# Altium schematic sheet 定位主控板.SchDoc(定位主控板)
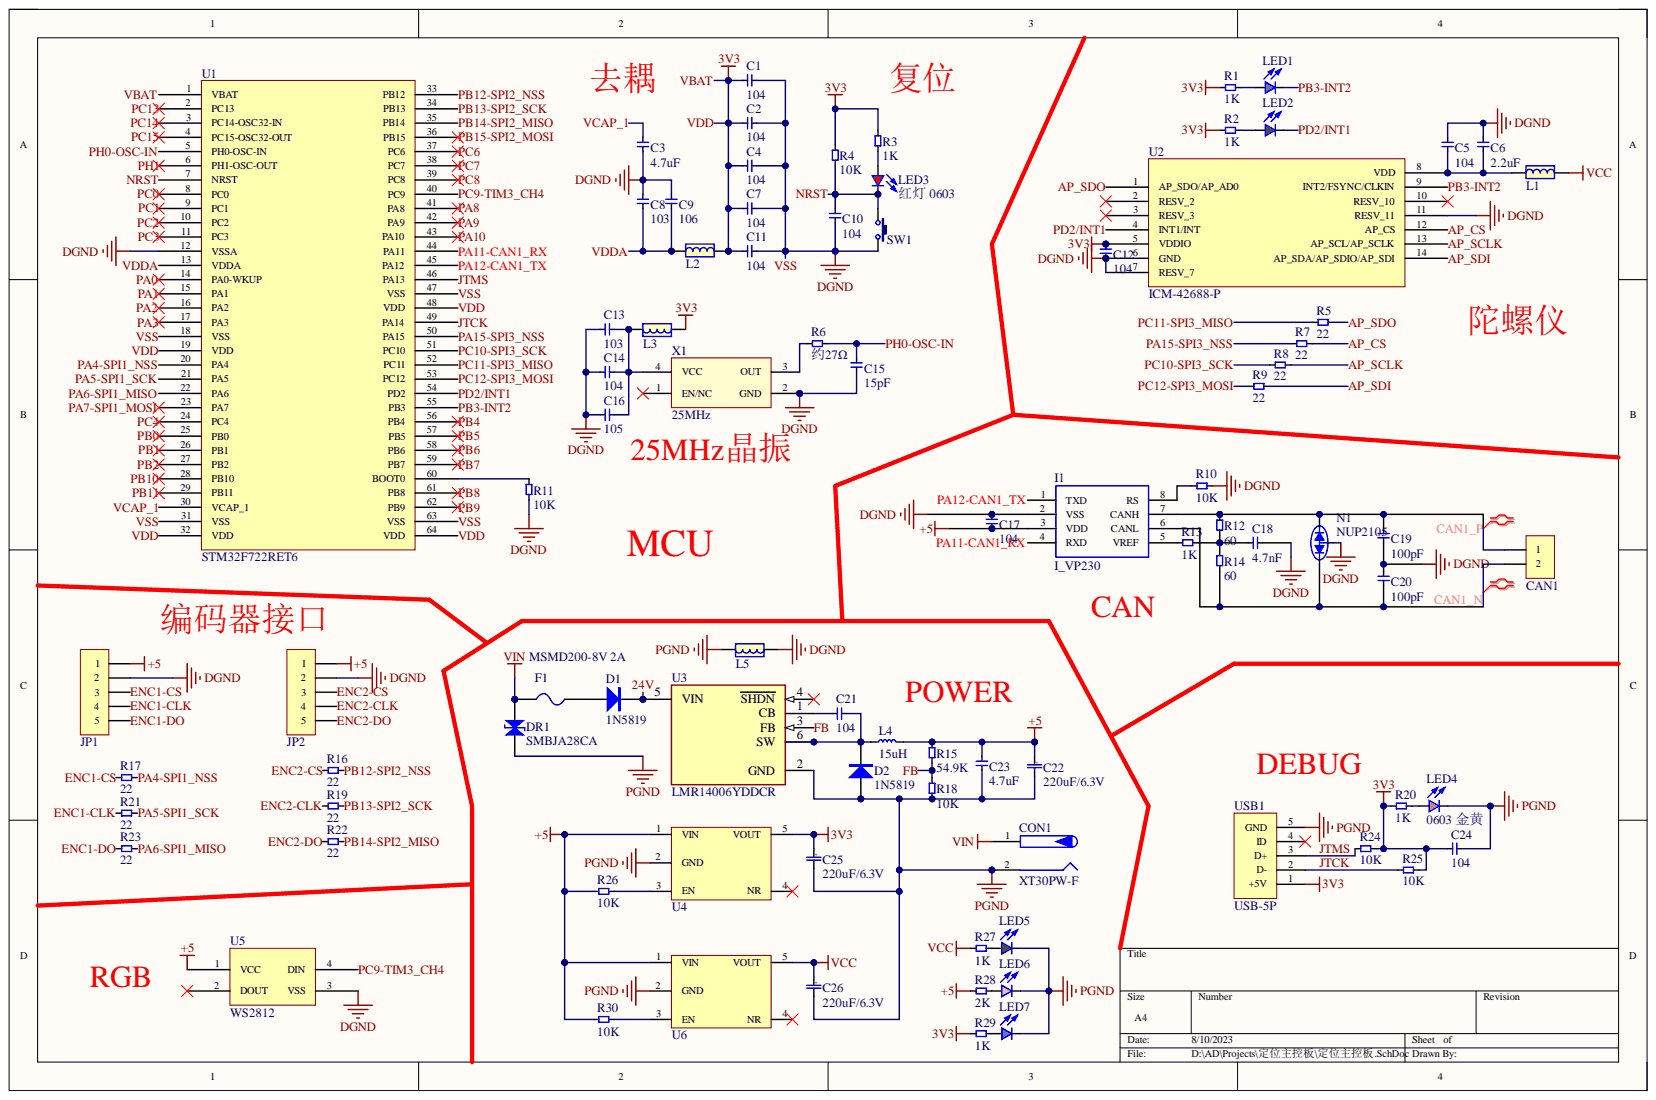
[diagram p0: 定位主控板.SchDoc]

## Parts
C1  104  [C_0603]
C2  104  [C_0603]
C3  4.7uF  [C_0603]
C4  104  [C_0603]
C5  104  [C_0603]
C6  2.2uF  [C_0603]
C7  104  [C_0603]
C8  103  [C_0603]
C9  106  [C_0603]
C10  104  [C_0603]
C11  104  [C_0603]
C12  104  [C_0603]
C13  103  [C_0603]
C14  104  [C_0603]
C15  15pF  [C_0603]
C16  105  [C_0603]
C17  104  [C_0603]
C18  4.7nF  [C_0603]
C19  100pF  [C_0603]
C20  100pF  [C_0603]
C21  104  [C_0603]
C22  220uF/6.3V  [C_T-B_1210/3528-21]
C23  4.7uF  [C_0603]
C24  104  [C_0603]
C25  220uF/6.3V  [C_T-B_1210/3528-21]
C26  220uF/6.3V  [C_T-B_1210/3528-21]
CAN1  HDR-1X2  [XH2.54-LS-2P]  (value not drawn)
CON1  XT30PW-F  [XT30PW-F]
D1  1N5819  [SOD-323]
D2  1N5819  [SOD-323]
DR1  SMBJA28CA  [瞬态抑制二极管]
F1  MSMD200-8V 2A  [FU 1206]
I1  I_VP230  [I_SOP-8_VP230]
JP1  HDR-1X5  [XH2.54-WS-5P]  (value not drawn)
JP2  HDR-1X5  [XH2.54-WS-5P]  (value not drawn)
L1  L  [FB 0603_L]
L2  L  [FB 0603_L]
L3  L  [FB 0603_L]
L4  15uH  [CD32]
L5  L  [L_磁珠0805]
LED1  蓝灯 0603  [0603_LED_S1]  (value not drawn)
LED2  绿灯 0603  [0603_LED_S1]  (value not drawn)
LED3  红灯 0603  [0603_LED_S1]
LED4  0603 金黄  [LED 0603]
LED5  绿灯 0603  [0603_LED_S1]  (value not drawn)
LED6  0603 粉  [0603_LED_S1]  (value not drawn)
LED7  蓝灯 0603  [0603_LED_S1]  (value not drawn)
N1  NUP2105  [SOT23-3N]
R1  1K  [R_0603]
R2  1K  [R_0603]
R3  1K  [R_0603]
R4  10K  [R_0603]
R5  22  [R_0603]
R6  约27Ω  [R_0603]
R7  22  [R_0603]
R8  22  [R_0603]
R9  22  [R_0603]
R10  10K  [R_0603]
R11  10K  [R_0603]
R12  60  [R_0603]
R13  1K  [R_0603]
R14  60  [R_0603]
R15  54.9K  [R_0603]
R16  22  [R_0603]
R17  22  [R_0603]
R18  10K  [R_0603]
R19  22  [R_0603]
R20  1K  [R_0603]
R21  22  [R_0603]
R22  22  [R_0603]
R23  22  [R_0603]
R24  10K  [R_0603]
R25  10K  [R_0603]
R26  10K  [R_0603]
R27  1K  [R_0603]
R28  2K  [R_0603]
R29  1K  [R_0603]
R30  10K  [R_0603]
SW1  3x6x5  [硅胶轻触开关]  (value not drawn)
U1  STM32F722RET6  [LQFP64 10x10_N]
U2  ICM-42688-P  [陀螺仪芯片]
U3  LMR14006YDDCR  [Texas_Instruments_DDC0006A_0]
U4  REG113-3.3  [REG113-3.3]  (value not drawn)
U5  WS2812  [WS2812B_B]
U6  REG113-3.3  [REG113-3.3]  (value not drawn)
USB1  USB-5P  [USB-MICRO_C]
X1  25MHz  [OSC 3225-4P]

## Nets
+5: C17.2 C22.1 C23.1 I1.3(VDD) JP1.1 JP2.1 L4.2 R15.2 R26.1 R28.1 R30.1 U4.1(VIN) U5.1(VCC) U6.1(VIN)
PGND: C22.2 C23.2 C24.2 C25.2 C26.2 CON1.2 D2.1 DR1.2 L5.2 LED4.K LED5.K LED6.K LED7.K R18.2 U3.2(GND) U4.2(GND) U6.2(GND) USB1.5(GND)
VCC: C26.1 L1.2 R27.1 U6.5(VOUT)
VIN: CON1.1 DR1.1 F1.1
24V: D1.2 U3.5(VIN)
AP_CS: R7.1 U2.12(AP_CS)
AP_SCLK: R8.1 U2.13(AP_SCL/AP_SCLK)
AP_SDI: R9.1 U2.14(AP_SDA/AP_SDIO/AP_SDI)
AP_SDO: R5.1 U2.1(AP_SDO/AP_AD0)
CAN1_N: C20.1 CAN1.2 I1.6(CANL) N1.2 R14.1
CAN1_P: C19.2 CAN1.1 I1.7(CANH) N1.1 R12.2
ENC1-CLK: JP1.4 R21.2
ENC1-CS: JP1.3 R17.2
ENC1-DO: JP1.5 R23.2
ENC2-CLK: JP2.4 R19.2
ENC2-CS: JP2.3 R16.2
ENC2-DO: JP2.5 R22.2
FB: R15.1 R18.1 U3.3(FB)
JTCK: R25.2 U1.49(PA14) USB1.2(D-)
JTMS: R24.2 U1.46(PA13) USB1.3(D+)
NRST: C10.2 LED3.K R4.2 SW1.1 U1.7(NRST)
PA0: U1.14(PA0-WKUP)
PA1: U1.15(PA1)
PA2: U1.16(PA2)
PA3: U1.17(PA3)
PA4-SPI1_NSS: R17.1 U1.20(PA4)
PA5-SPI1_SCK: R21.1 U1.21(PA5)
PA6-SPI1_MISO: R23.1 U1.22(PA6)
PA7-SPI1_MOSI: U1.23(PA7)
PA8: U1.41(PA8)
PA9: U1.42(PA9)
PA10: U1.43(PA10)
PA11-CAN1_RX: I1.4(RXD) U1.44(PA11)
PA12-CAN1_TX: I1.1(TXD) U1.45(PA12)
PA15-SPI3_NSS: R7.2 U1.50(PA15)
PB0: U1.25(PB0)
PB1: U1.26(PB1)
PB2: U1.27(PB2)
PB3-INT2: LED1.K U1.55(PB3) U2.9(INT2/FSYNC/CLKIN)
PB4: U1.56(PB4)
PB5: U1.57(PB5)
PB6: U1.58(PB6)
PB7: U1.59(PB7)
PB8: U1.61(PB8)
PB9: U1.62(PB9)
PB10: U1.28(PB10)
PB11: U1.29(PB11)
PB12-SPI2_NSS: R16.1 U1.33(PB12)
PB13-SPI2_SCK: R19.1 U1.34(PB13)
PB14-SPI2_MISO: R22.1 U1.35(PB14)
PB15-SPI2_MOSI: U1.36(PB15)
PC0: U1.8(PC0)
PC1: U1.9(PC1)
PC2: U1.10(PC2)
PC3: U1.11(PC3)
PC4: U1.24(PC4)
PC6: U1.37(PC6)
PC7: U1.38(PC7)
PC8: U1.39(PC8)
PC9-TIM3_CH4: U1.40(PC9) U5.4(DIN)
PC10-SPI3_SCK: R8.2 U1.51(PC10)
PC11-SPI3_MISO: R5.2 U1.52(PC11)
PC12-SPI3_MOSI: R9.2 U1.53(PC12)
PC13: U1.2(PC13)
PC14: U1.3(PC14-OSC32-IN)
PC15: U1.4(PC15-OSC32-OUT)
PD2/INT1: LED2.K U1.54(PD2) U2.4(INT1/INT)
PH0-OSC-IN: C15.2 R6.2 U1.5(PH0-OSC-IN)
PH1: U1.6(PH1-OSC-OUT)
VBAT: C1.1 C2.1 C4.1 C7.1 C11.1 C12.2 C24.1 C25.1 L2.2 L3.1 R1.2 R2.2 R3.1 R4.1 R20.1 R24.1 R25.1 R29.1 U1.1(VBAT) U1.19(VDD) U1.32(VDD) U1.48(VDD) U1.64(VDD) U2.5(VDDIO) U4.5(VOUT) USB1.1(+5V)
VCAP_1: C3.2 U1.30(VCAP_1)
VDDA: C8.1 C9.1 L2.1 U1.13(VDDA)
VSS: C1.2 C2.2 C3.1 C4.2 C5.2 C6.2 C7.2 C8.2 C9.2 C10.1 C11.2 C12.1 C13.1 C14.1 C15.1 C16.1 C17.1 C18.2 C19.1 C20.2 I1.2(VSS) JP1.2 JP2.2 L5.1 N1.3 R10.1 R11.2 SW1.2 U1.12(VSSA) U1.18(VSS) U1.31(VSS) U1.47(VSS) U1.63(VSS) U2.6(GND) U2.7(RESV_7) U2.11(RESV_11) U5.3(VSS) X1.2(GND)
NetC5_1: C5.1 C6.1 L1.1 U2.8(VDD)
NetC13_2: C13.2 C14.2 C16.2 L3.2 X1.4(VCC)
NetC18_1: C18.1 R12.1 R13.1 R14.2
NetC21_1: C21.1 D2.2 L4.1 U3.6(SW)
NetC21_2: C21.2 U3.1(CB)
NetD1_1: D1.1 F1.2
NetI1_5: I1.5(VREF) R13.2
NetI1_8: I1.8(RS) R10.2
NetLED1_A: LED1.A R1.1
NetLED2_A: LED2.A R2.1
NetLED3_A: LED3.A R3.2
NetLED4_A: LED4.A R20.2
NetLED5_A: LED5.A R27.2
NetLED6_A: LED6.A R28.2
NetLED7_A: LED7.A R29.2
NetR6_1: R6.1 X1.3(OUT)
NetR11_1: R11.1 U1.60(BOOT0)
NetR26_2: R26.2 U4.3(EN)
NetR30_2: R30.2 U6.3(EN)

Not connected: U2 2(RESV_2) 3(RESV_3) 10(RESV_10); U3 4(SHDN); U4 4(NR); U5 2(DOUT); U6 4(NR); USB1 4(ID); X1 1(EN/NC)

## Library data of the parts
C1-C21 C23 C24 = C_无极贴片: 无极性贴片电容; Component Kind: Standard; Library Name: 电阻电容电感库.IntLib
C22 C25 C26 = C_钽电容: 有极性贴片钽电容; Component Kind: Standard; Library Name: 电阻电容电感库.IntLib
CAN1 = Header 2: 2P接插件; BOM名称: 2P接插件; Component Kind: Standard; Library Name: 4.6   - 接插件-脚距2.54.SchLib
CON1 = XT30PW-F: XT30PW-F PCB板专用卧式连接器，低温升阻燃插头; Component Kind: Standard; Library Name: AMASS.IntLib
D1 D2 = 1N5819: 肖特基二极管; 属性: 40V/2A; BOM名称: 肖特基二极管; Component Kind: Standard; Library Name: 8.1   - 二极管-整流桥.SchLib
DR1 = DR: 瞬态电压抑制二极管; BOM名称: 瞬态电压抑制二极管; Component Kind: Standard; Library Name: 8.1   - 二极管-整流桥.SchLib
F1 = FU: 贴片保险丝; BOM名称: 贴片保险丝; Component Kind: Standard; Library Name: 10.1 - 保险丝-晶振.SchLib
I1 = I_VP230: Can 总线收发器; Component Kind: Standard; Library Name: 集成器件.IntLib
JP1 JP2 = Header 5: 5P接插件; BOM名称: 5P接插件; Component Kind: Standard; Library Name: 4.6   - 接插件-脚距2.54.SchLib
L1-L3 L5 = L_磁珠: 磁珠; Component Kind: Standard; Library Name: 电阻电容电感库.IntLib
L4 = L_贴片功率: 贴片屏蔽式功率电感; Component Kind: Standard; Library Name: 电阻电容电感库.IntLib
LED1 LED7 = 0603 蓝灯: 发光二极管; Component Kind: Standard; ComponentLink1Description: 供应商链接; ComponentLink1URL: http://www.szlcsc.com/product/details_73150.html; Library Name: LED.SchLib; Package: 0603; Supplier: LC; SuppliersPartNumber: C72041
LED2 LED5 = 0603 绿灯: 发光二极管; Component Kind: Standard; ComponentLink1Description: 供应商链接; ComponentLink1URL: http://www.szlcsc.com/product/details_73152.html; Library Name: LED.SchLib; Package: 0603; Supplier: LC; SuppliersPartNumber: C72043
LED3 = 0603 红灯: 发光二极管; Component Kind: Standard; ComponentLink1Description: 供应商链接; ComponentLink1URL: http://www.szlcsc.com/product/details_2659.html; Library Name: LED.SchLib; Package: 0603; Supplier: LC; SuppliersPartNumber: C2286
R1-R30 = R_贴片: 贴片电阻; Component Kind: Standard; Library Name: 电阻电容电感库.IntLib
SW1 = TSW 3x6: 3x6轻触开关; BOM名称: 3x6轻触开关; Component Kind: Standard; Library Name: 5.1   - 按键-开关.SchLib
U3 = LMR14006YDDCR: SIMPLE SWITCHER® 4-V to 40-V, 600-mA step-down converter with Low Iq 6-SOT-23-THIN -40 to 125; Component Kind: Standard; Library Name: 钝角.IntLib
U4 U6 = REG113-3.3: 3.3V稳压电源; Component Kind: Standard; Library Name: 哧哧_Library.IntLib
USB1 = USB-5P: 微型USB母座; BOM名称: 微型USB母座; Component Kind: Standard; Library Name: 4.1   - 接口类.SchLib
X1 = XTAL-ACT-4P: 4脚有源晶振; BOM名称: 4脚有源晶振; Component Kind: Standard; Library Name: 10.1 - 保险丝-晶振.SchLib
LED4 = 0603 金黄: Component Kind: Standard; Library Name: LED.SchLib
LED6 = 0603 粉: Component Kind: Standard; Library Name: LED.SchLib
N1 = NUP2105: Component Kind: Standard; Library Name: 8.1   - 二极管-整流桥.SchLib
U1 = STM32F722RET6: Component Kind: Standard; Library Name: Schlib1.SchLib
U2 = ICM-42688-P: Component Kind: Standard; Library Name: Schlib1.SchLib
U5 = WS2812: Component Kind: Standard; Library Name: 9.1   - LED.SchLib
